# Altium schematic sheet AIn_Isolator.SchDoc
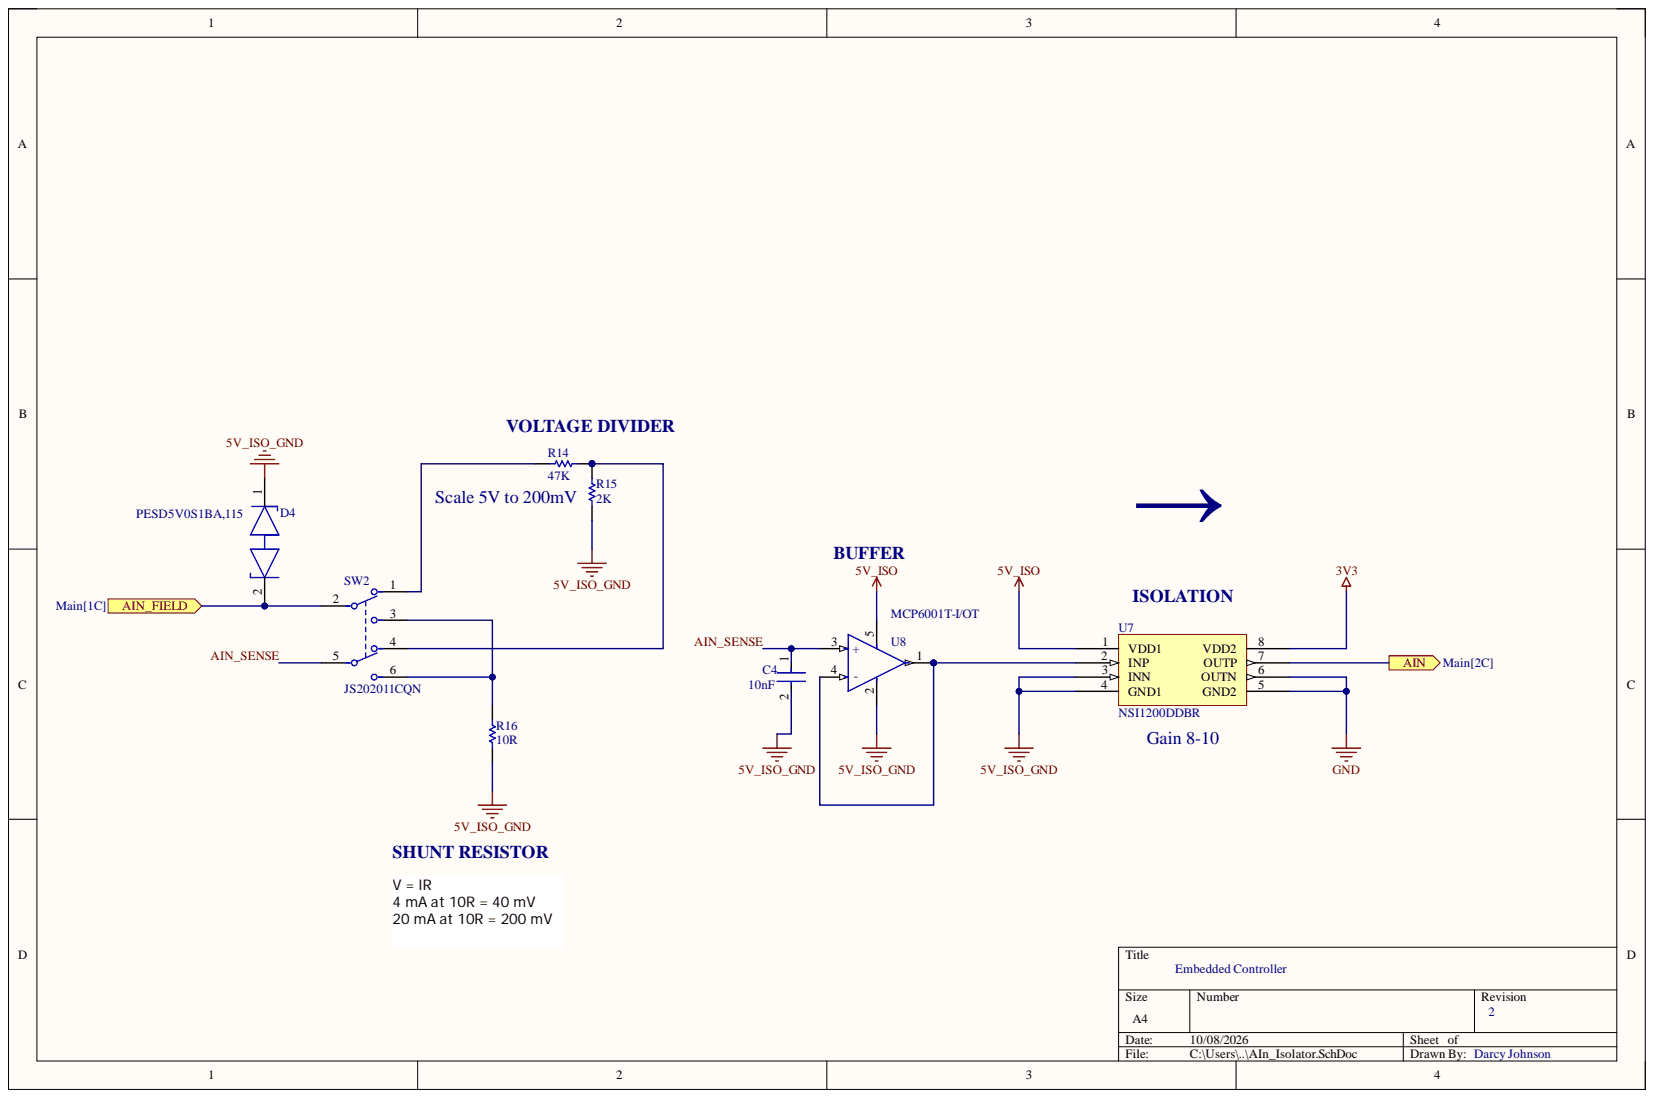
[diagram p0: AIn_Isolator.SchDoc]

## Parts
C4  10nF  [FP-CC0603-DA-MFG]
D4  PESD5V0S1BA,115  [FP-SOD323-CA-MFG]
R14  47K  [RESC1608X55X30NL15T15]
R15  2K  [RESC1608X55X30ML15T15]
R16  10R  [RESC3216X70X50LL20T25]
SW2  JS202011CQN  [FP-JS202011CQN-MFG - duplicate]
U7  NSI1200DDBR  [NSI1200DDBR]
U8  MCP6001T-I/OT  [FP-C04-091-OT-IPC_A]

## Ports
AIN, AIN_FIELD

## Nets
3V3: U7.8(VDD2)
5V_ISO: U7.1(VDD1) U8.5
5V_ISO_GND: C4.2 D4.1 R15.1 R16.2 U7.3(INN) U7.4(GND1) U8.2
GND: U7.5(GND2) U7.6(OUTN)
AIN: U7.7(OUTP) ; port AIN
AIN_FIELD: D4.2 SW2.2 ; port AIN_FIELD
AIN_SENSE: C4.1 SW2.5 U8.3(+)
NetR14_1: R14.1 SW2.1
NetR14_2: R14.2 R15.2 SW2.4
NetR16_1: R16.1 SW2.3 SW2.6
NetU7_2: U7.2(INP) U8.1 U8.4(-)

## Library data of the parts
C4 = CMP-2100-03600-2: CAP CER 10000PF 50V X7R 0603; Manufacturer: YAGEO; Manufacturer Part Number: CC0603KRX7R9BB103; Material: Ceramic; Temperature Coefficient: 15%; Tolerance: 0.1; Automotive: No; Capacitance: 10 nF; Category: Cap; Component Kind: Standard; ComponentLink2Description: Datasheet; ComponentLink2URL: http://www.yageo.com/documents/recent/UPY-GPHC_X7R_6.3V-to-50V_18.pdf; Device Class L1: Passive Components; Device Class L2: Capacitors; Device Class L3: Ceramic Capacitors; Digikey Description: CAP CER 10000PF 50V X7R 0603; Footprint Url: http://www.yageo.com/exep/pages/download/literatures/UPY-C_GEN_24.pdf; Height: 0.9mm; Lead Free: Yes; Library Name: Altium Content Vault; Mouser Description: Multilayer Ceramic Capacitor, CC Series, 0.01 - F, - 10%, X7R, 50 V, 0603 [1608 Metric]; Octopart: https://octopart.com/cc0603krx7r9bb103-yageo-192938; Package: CC0603-DA; RoHS: TRUE; Temperature: -55°C to +125°C; Temperature Characteristic: X7R; Temperature Range High: +125°C; Temperature Range Low: -55°C; Value: 10 nF; Voltage: 50 V; Voltage Rating: 50 V
D4 = CMP-14432-000001-1: TVS DIODE 5V 14V SOD323; Component Kind: Standard; Library Name: Altium Content Vault
R14 = CMP-2000-00523-2: Chip Resistor, 47 KOhm, +/- 1%, 0.1 W, -55 to 155 degC, 0603 (1608 Metric), RoHS, Tape and Reel; Case\Package: 0603; Forward Current: 20 mA; Forward Voltage: 2.1 V; Illumination Colour: Red; Lens Style: Clear; Luminous Intensity: 63 mcd; Nominal Wavelength: 639 nm; Power Rating: 90 mW; Viewing Angle: 160 degrees; Case Code (Imperial): 0603; Case Code (Metric): 1608; Colour: Red; Component Kind: Standard; ComponentLink1Description: Manufacturer URL; ComponentLink1URL: http://www.panasonic.com/; ComponentLink2Description: Datasheet; ComponentLink2URL: http://industrial.panasonic.com/cdbs/www-data/pdf/RDA0000/AOA0000C86.pdf; DatasheetVersion: Apr-15; Height: 0.6 mm; Length: 1.6 mm; Library Name: Altium Content Vault; Max Operating Temperature: 100 degC; Min Operating Temperature: -40 degC; Mount: Surface Mount; Nominal Vf: 2.1 V; Package Quantity: 3000; PackageDescription: 2-Pin Surface Mount Device, Body 1.6 x 0.85 mm; PackageReference: 0603; Packaging: Tape and Reel; Pins: 2; Radiation Hardening: No; REACH SVHC: No SVHC; RoHSCompliant: true; Width: 0.8 mm
R15 = CMP-2000-00317-1: Manufacturer: Panasonic; Part Number: ERJ-3EKF2001V; Component Kind: Standard; Library Name: Altium Content Vault
R16 = CMP-2003-04407-1: Manufacturer: Panasonic; Part Number: ERA8AEB103V; Component Kind: Standard; Library Name: Altium Content Vault
SW2 = CMP-10038-000022-1_1: SWITCH SLIDE DPDT 300MA 6V; Actuator Color: Black; Actuator Length: 2mm; Actuator Material: Nylon; Actuator Type: Standard; Contact Current Rating: 100mA; Contact Resistance: 70mR; Electrical Life: 5000Cycles; Illumination Type: Non-Illuminated; Switch Function: On-On; Component Kind: Standard; Contact Material: Copper Alloy; Contact Plating: Silver; Current Rating: 300mA; Depth: 3.6mm; Flammability Rating: UL94 V-0; Housing Material: Nylon; Lead Free: Lead Free; Length: 9mm; Library Name: JS202011CQN .SchLib; Max Current Rating: 300mA; Max Operating Temperature: 85°C; Max Voltage Rating (DC): 6V; Min Operating Temperature: -40°C; Mount: Through Hole; Orientation: Vertical; Package Quantity: 1; Packaging: Bulk; Radiation Hardening: No; REACH SVHC: No SVHC; RoHS Compliant: Yes; Series: JS; Switch Travel: 2mm; Termination: PC Pins; Throw Configuration: DPDT; Voltage Rating (DC): 6V
U7 = NSI1200DDBR: High Reliability Reinforced Isolated Amplifier; Manufacturer: Novosense; Component Kind: Standard; ComponentLink1Description: Datasheet; ComponentLink1URL: https://file.elecfans.com/web2/M00/63/D8/poYBAGMEo2GAVfdLABzacvfTaPY750.pdf; Library Name: nsi1200.IntLib; Manufacturer Part Number: NSI1200DDBR
U8 = CMP-0187-00462-3: IC OPAMP GP 1 CIRCUIT SOT23-5; Bandwidth: 1MHz; Common Mode Rejection Ratio: 60dB; Input Bias Current: 1pA; Input Offset Voltage (Vos): 4.5mV; Max Supply Voltage: 6V; Min Supply Voltage: 1.8V; Nominal Supply Current: 100uA; Number of Amplifiers: 1; Operating Supply Voltage: 5.5V; Output Current: 23mA; Power Supply Rejection Ratio (PSRR): 86dB; Slew Rate: 0.6V/µs; Voltage Gain: 112dB; Case/Package: SOT-23; Component Kind: Standard; Contact Plating: Tin; Gain Bandwidth Product: 1MHz; Height: 1.3mm; Lead Free: Lead Free; Length: 3.1mm; Library Name: Altium Content Vault; Max Operating Temperature: 85°C; Min Operating Temperature: -40°C; Mount: Surface Mount; Number of Channels: 1; Number of Circuits: 1; Number of Elements: 1; Number of Pins: 5; Operating Supply Current: 100uA; Output Current per Channel: 23mA; Package Quantity: 1; Packaging: Tape and Reel; Radiation Hardening: No; REACH SVHC: No SVHC; Unity Gain Bandwidth Product: 1MHz; Voltage: 6V; Width: 1.8mm
